# Altium schematic sheet A4-B.SchDoc
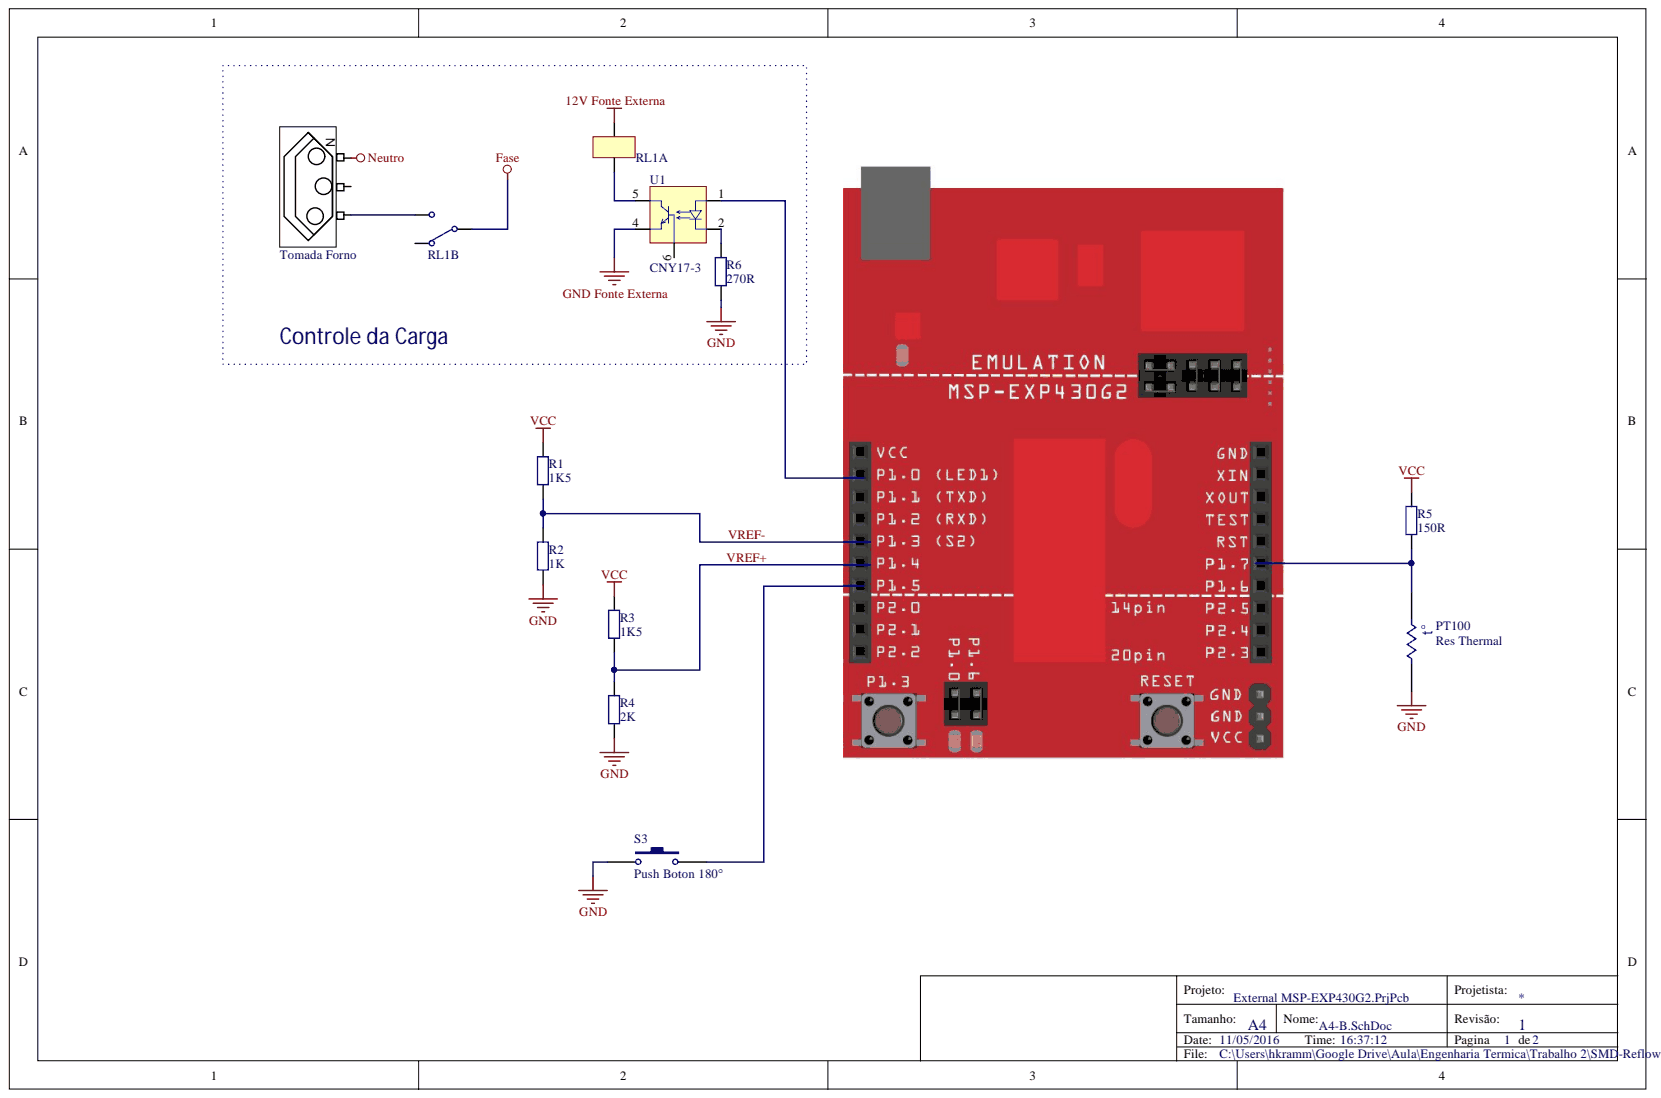
[diagram p0: A4-B.SchDoc]

## Parts
PT100  Res Thermal  [6-0805_N]
R1  value 1K5  [Resistor 1/8]  (hidden comment: Res 1K5)
R2  value 1K  [Resistor 1/8]  (hidden comment: Res 1K)
R3  value 1K5  [Resistor 1/8]  (hidden comment: Res 1K5)
R4  value 2K  [Resistor 1/8]  (hidden comment: Res 2K)
R5  value 150R  [Resistor 1/8]  (hidden comment: Res 150R)
R6  value 270R  [Resistor 1/8]  (hidden comment: Res 270R)
RL1  RELE 1NA 1NF  [rele SRA]  (value not drawn)  (parts A,B)
S3  Push Boton 180°  [PushButton - small - 2]
Tomada_Forno  TOMADA AC RETA  [EX-1401]  (value not drawn)  (mechanical)
U1  CNY17-3  [PDIP300-P6]

## Nets
12V FONTE EXTERNA: RL1.1
FASE: RL1.3
GND: PT100.2 R2.1 R4.1 R6.1 S3.1
GND FONTE EXTERNA: U1.4
NEUTRO: Tomada_Forno.3
VCC: R1.2 R3.2 R5.2
VREF+: R3.1 R4.2
VREF-: R1.1 R2.2
NetPT100_1: PT100.1 R5.1
NetR6_2: R6.2 U1.2
NetRL1_2: RL1.2 U1.5
NetRL1_5: RL1.5 Tomada_Forno.1

Not connected: RL1 4; S3 2; Tomada_Forno 2; U1 1 6

## Library data of the parts
PT100 = Res Thermal: Thermistor; Code_IPC: RESC2012; Component Kind: Standard; LatestRevisionDate: 29-May-2009; LatestRevisionNote: IPC-7351 Footprint Added.; Library Name: Miscellaneous Devices.IntLib; PackageDescription: Chip Resistor 0805 Case Size; PackageReference: 6-0805; PackageVersion: Mar-2003; Published: 24-Mar-1999; Publisher: Altium Limited
R1 R3 = Res 1K5: Resistor; Almox.Gaia: RESISTOR    1K5; Código: 000699; Component Kind: Standard; LatestRevisionDate: 22-Mar-2011; LatestRevisionNote: Re-released for DXP Platform.; Library Name: almox Gaia.SchLib; PackageReference: AXIAL-0.4; Published: 8-Jun-2000; Signal Integrity: Res; Simulation: RESISTOR; Publisher: Altium Limited
R2 = Res 1K: Resistor; Almox.Gaia: RESISTOR    1K; Código: 000698; Component Kind: Standard; LatestRevisionDate: 22-Mar-2011; LatestRevisionNote: Re-released for DXP Platform.; Library Name: almox Gaia.SchLib; PackageReference: AXIAL-0.4; Published: 8-Jun-2000; Signal Integrity: Res; Simulation: RESISTOR; Publisher: Altium Limited
R4 = Res 2K2: Resistor; Almox.Gaia: RESISTOR    2K; Código: 000700; Component Kind: Standard; LatestRevisionDate: 22-Mar-2011; LatestRevisionNote: Re-released for DXP Platform.; Library Name: almox Gaia.SchLib; PackageReference: AXIAL-0.4; Published: 8-Jun-2000; Signal Integrity: Res; Simulation: RESISTOR; Publisher: Altium Limited
R5 = Res 180R: Resistor; Almox.Gaia: RESISTOR 150R; Código: 000714; Component Kind: Standard; LatestRevisionDate: 22-Mar-2011; LatestRevisionNote: Re-released for DXP Platform.; Library Name: almox Gaia.SchLib; PackageReference: AXIAL-0.4; Published: 8-Jun-2000; Signal Integrity: Res; Simulation: RESISTOR; Publisher: Altium Limited
R6 = Res 270R: Resistor; Almox.Gaia: RESISTOR 270R; Código: 000716; Component Kind: Standard; LatestRevisionDate: 22-Mar-2011; LatestRevisionNote: Re-released for DXP Platform.; Library Name: almox Gaia.SchLib; PackageReference: AXIAL-0.4; Published: 8-Jun-2000; Signal Integrity: Res; Simulation: RESISTOR; Publisher: Altium Limited
RL1 = RELE 1NA 1NF: Relay; Manufacturer: Metaltex; Almox.Gaia: RELE SRA 12V DC; Class I: Electro-mechanical; Código: 000697; Class II: Relay; Component Kind: Standard; Library Name: almox Gaia.SchLib; Published: 23-may-2004; Publisher: Gaia Component
S3 = Push Boton 180°: Switch; Almox.Gaia: CHAVE TACTIL 3,4 x 6 x 2,5 180°; Código: 7325; Component Kind: Standard; LatestRevisionDate: 25-out-2011; LatestRevisionNote: Re-released for DXP Platform.; Library Name: almox Gaia.SchLib; PackageReference: SPST-2; Published: 24-Mar-1999; Publisher: Altium Limited
Tomada_Forno = TOMADA AC RETA: TOMADA AC; Almox.Gaia: TOMADA DE FORÇA RETA 1401PT; Código: 003628; LatestRevisionDate: 22-Mar-2011; LatestRevisionNote: Re-released for DXP Platform.; Library Name: almox Gaia.SchLib; Publisher: Altium Limited
U1 = CNY17-3: Optocoupler, Phototransistor Output, Dual Channel, TTL Compatible, Pb-free; Almox.Gaia: CI CNY 17-3; Código: 000463; Note: Transfer Ratio, 35 % Typical; Note: Coupling Capacitance, 0.5 pF; Component Kind: Standard; ComponentLink1Description: Manufacturer Link; ComponentLink1URL: http://www.vishay.com/optocouplers/list/product-83640/; ComponentLink2Description: Datasheet; ComponentLink2URL: http://www.vishay.com/docs/83640/83640.pdf; DatasheetDocument: Rev. 1.4, Oct-2004; Library Name: almox Gaia.SchLib; PackageDocument: Dec-2003; PackageReference: DIP6; Published: 6-Oct-2005; Publisher: Altium Limited
